# Altium schematic sheet [2]-Power supply.SchDoc("[2]-Power supply")
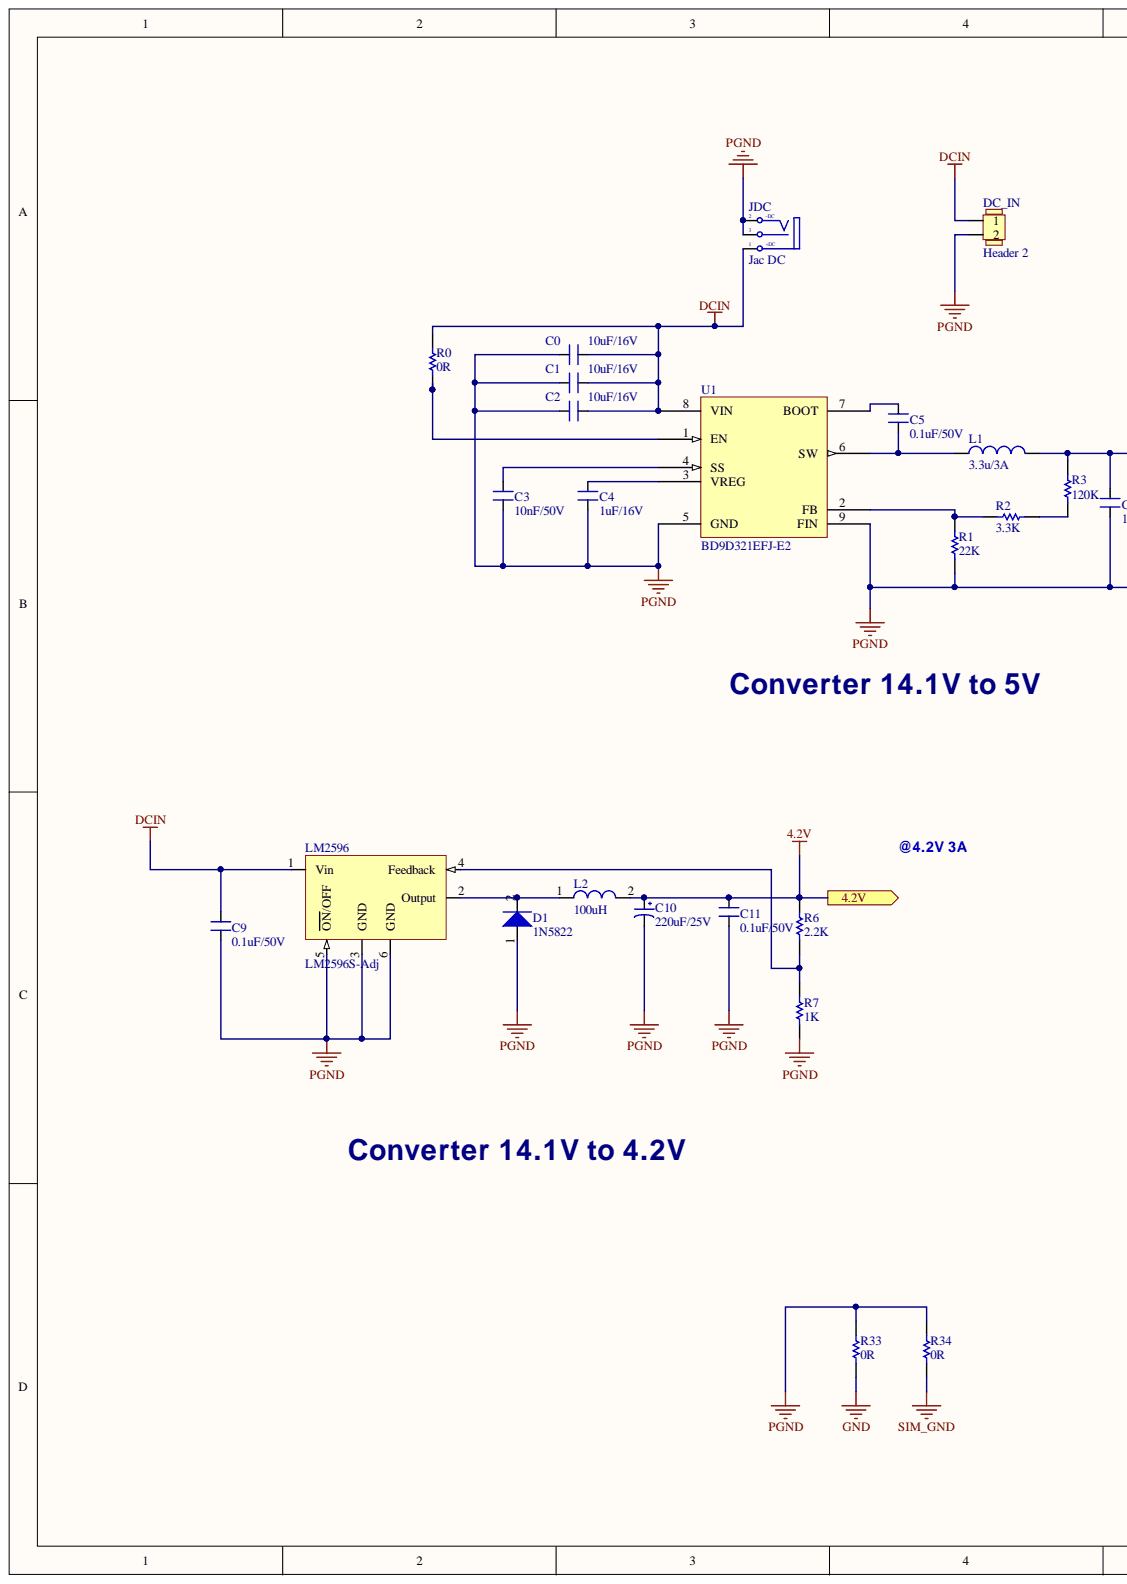
[diagram p0: [2]-Power supply.SchDoc, left]
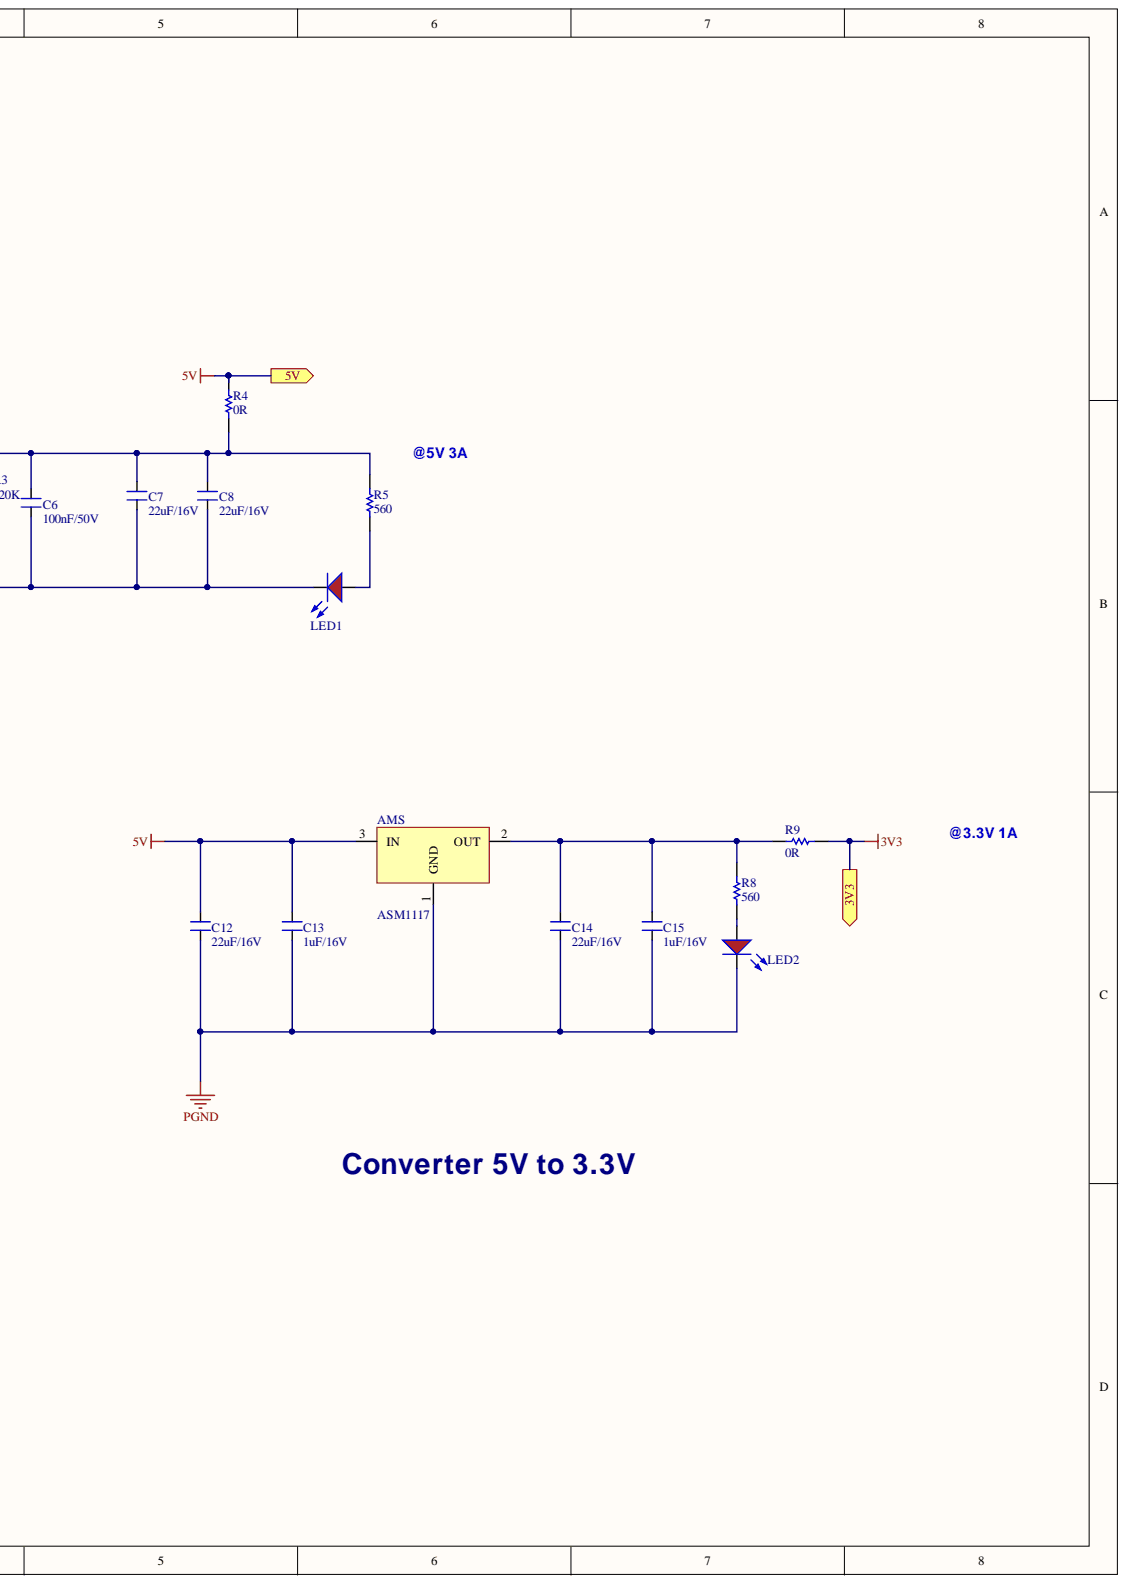
[diagram p1: [2]-Power supply.SchDoc, right]

## Parts
AMS  ASM1117  [SOT223]
C0  10uF/16V  [CAP 0603(1508)]
C1  10uF/16V  [CAP 0603(1508)]
C2  10uF/16V  [CAP 0603(1508)]
C3  10nF/50V  [CAP 0603(1508)]
C4  1uF/16V  [CAP 0603(1508)]
C5  0.1uF/50V  [CAP 0603(1508)]
C6  100nF/50V  [CAP 0603(1508)]
C7  22uF/16V  [CAP 1210(3225)]
C8  22uF/16V  [CAP 1210(3225)]
C9  0.1uF/50V  [CAP 0603(1508)]
C10  220uF/25V  [CAP_ALU_SIZEE]
C11  0.1uF/50V  [CAP 0603(1508)]
C12  22uF/16V  [CAP 1210(3225)]
C13  1uF/16V  [CAP 0603(1508)]
C14  22uF/16V  [CAP 1210(3225)]
C15  1uF/16V  [CAP 0603(1508)]
D1  1N5822  [1N5822 SS34A]
DC_IN  Header 2  [HEADER x2 (2)]
JDC  Jac DC  [DC socket]
L1  3.3u/3A  [NRS5040T3R3NMGJ]
L2  100uH  [Inductor SMD 12x12x8 mm]
LED1  LED_RED  [LED0805RED]  (value not drawn)
LED2  LED_RED  [LED0805RED]  (value not drawn)
LM2596  LM2596S-Adj  [LM2596S TO263-5]
R0  0R  [RES 0603(1608)]
R1  22K  [RES 0603(1608)]
R2  3.3K  [RES 0603(1608)]
R3  120K  [RES 0603(1608)]
R4  0R  [Res 0805(2012)]
R5  560  [RES 0603(1608)]
R6  2.2K  [RES 0603(1608)]
R7  1K  [RES 0603(1608)]
R8  560  [RES 0603(1608)]
R9  0R  [RES 0603(1608)]
R33  0R  [RES 1206(3216)]
R34  0R  [RES 1206(3216)]
U1  BD9D321EFJ-E2  [HTsop j8]

## Ports
3V3, 4.2V, 5V

## Nets
DCIN: C0.2 C1.2 C2.2 C9.2 DC_IN.1 JDC.1 LM2596.1(Vin) R0.1 U1.8(VIN)
GND: R33.2
PGND: AMS.1 C0.1 C1.1 C2.1 C3.1 C4.1 C6.2 C7.2 C8.2 C9.1 C10.2 C11.1 C12.2 C13.1 C14.2 C15.1 D1.1 DC_IN.2 JDC.2 JDC.3 LED1.2 LED2.2 LM2596.3(GND) LM2596.5(ON/OFF) LM2596.6(GND) R1.2 R7.2 R33.1 R34.1 U1.5(GND) U1.9(FIN)
SIM_GND: R34.2
3V3: R9.1 ; port 3V3
4.2V: C10.1 C11.2 L2.2 R6.1 ; port 4.2V
5V: AMS.3 C12.1 C13.2 R4.1 ; port 5V
NetAMS_2: AMS.2 C14.1 C15.2 R8.1 R9.2
NetC3_2: C3.2 U1.4(SS)
NetC4_2: C4.2 U1.3(VREG)
NetC5_1: C5.1 L1.1 U1.6(SW)
NetC5_2: C5.2 U1.7(BOOT)
NetC6_1: C6.1 C7.1 C8.1 L1.2 R3.1 R4.2 R5.1
NetD1_2: D1.2 L2.1 LM2596.2(Output)
NetLED1_1: LED1.1 R5.2
NetLED2_1: LED2.1 R8.2
NetLM2596_4: LM2596.4(Feedback) R6.2 R7.1
NetR0_2: R0.2 U1.1(EN)
NetR1_1: R1.1 R2.1 U1.2(FB)
NetR2_2: R2.2 R3.2

## Library data of the parts
AMS = ASM1117: ASM1117 3.3V; Library Name: Library.SchLib; Component Kind: Standard
C0-C2 = CAP_CER_10uF_16V_NPOL: Ceramic Capacitor 10uF/16V (+-10% TH)/(+10%SMD); Component Kind: Standard; : 10uF/35V; Library Name: Duc.SchLib
C3 = CAP_CER_10nF_50V_NPOL: Ceramic Capacitor 10nF/50V (+-10% TH)/(+10%SMD); Component Kind: Standard; Library Name: Duc.SchLib
C4 C13 C15 = CAP_CER_1uF_16V_NPOL: Ceramic Capacitor 1uF/16V (+-10% TH)/(+10%SMD); Component Kind: Standard; Library Name: Duc.SchLib
C5 C9 C11 = CAP_CER_0.1uF_50V_NPOL_1: Ceramic Capacitor 1nF/50V (+-20% TH)/(+10%SMD); Component Kind: Standard; Library Name: Duc.SchLib
C6 = CAP_CER_100nF_50V_NPOL: Ceramic Capacitor 100nF/50V (+-20% TH)/(+10%SMD); Component Kind: Standard; Library Name: Duc.SchLib
C7 C8 C12 C14 = CAP_CER_22uF_16V_NPOL: Ceramic Capacitor 22uF/16V (+-10% TH)/(+5%SMD); Component Kind: Standard; Library Name: Duc.SchLib
C10 = CAP_ALU_220uF_25V_POL_SMD: Capacitor Aluminum 220uF/25V size E; Component Kind: Standard; Library Name: Duc.SchLib
D1 = 1N5822_2: Description: 1N5822; Component Kind: Standard; Library Name: Duc.SchLib
JDC = DCIN: Jac DC input; Value: Jac DC; Component Kind: Standard; Library Name: Duc.SchLib
L1 = L HCC: Manufacturer: Taiyo Yuden; Description Std: FIXED IND 3.3UH 3.3A 27 MOHM SMD; Value: 3.3u/3A; Package: Nonstandard; Manufacturer Part Number: NRS5040T3R3NMGJ; Library Name: mLib.SchLib; Digikey price/1Unit: 0.38; Digikey Price/1KU: 0.19594; Digikey Link: https://www.digikey.com/product-detail/en/taiyo-yuden/NRS5040T3R3NMGJ/587-5939-2-ND/4970846?fbclid=IwAR1TO128Zn-Cm4djbx0dlA4gExl6Xq8ch3GbM3Ce9mHcaeqXS-1W4rWjcVA; Component Kind: Standard; Category: Inductor
L2 = Inductor: Library Name: Duc.SchLib; Component Kind: Standard
LED1 LED2 = LED_RED: LED Red; Value: Red; Unit Price: 0.0476; ComponentLink1Description: Datasheet; Component Kind: Standard; Library Name: Duc.SchLib; ComponentLink1URL: D:\Dropbox\Datasheets\Opto and Illumination\LEDs\SMD LED.pdf
LM2596 = LM2596S-Adj: IC LM2596S buck 3A; Component Kind: Standard; Library Name: Duc.SchLib
R0-R3 R5-R9 = Resistor 0603: Description: Resistor 0603; Component Kind: Standard; Library Name: Duc.SchLib
R4 = Resistor 0805: Description: Resistor 0805; Component Kind: Standard; Library Name: Duc.SchLib
R33 R34 = Resistor 1206: Description: Resistor 1206; Component Kind: Standard; Library Name: Duc.SchLib
U1 = BD9D321EFJ: Manufacturer: Rohm Semiconductor; Description Std: IC REG BUCK ADJ 3A SYNC 8HTSOP; Value: BD9D321EFJ-E2; Package: 8-SOIC (0.154", 3.90mm Width) Exposed Pad; Manufacturer Part Number: BD9D321EFJ-E2; Digikey price/1Unit: 0.73; Digikey Price/1KU: 0.29005; Digikey Link: https://www.digikey.com/product-detail/en/rohm-semiconductor/BD9D321EFJ-E2/BD9D321EFJ-E2CT-ND/4625913; Component Kind: Standard; Category: IC; Library Name: IOC V1.0 Logistic.SCHLIB
DC_IN = Header 2: Component Kind: Standard; Library Name: Duc.SchLib
